# Altium schematic sheet Analog.SchDoc
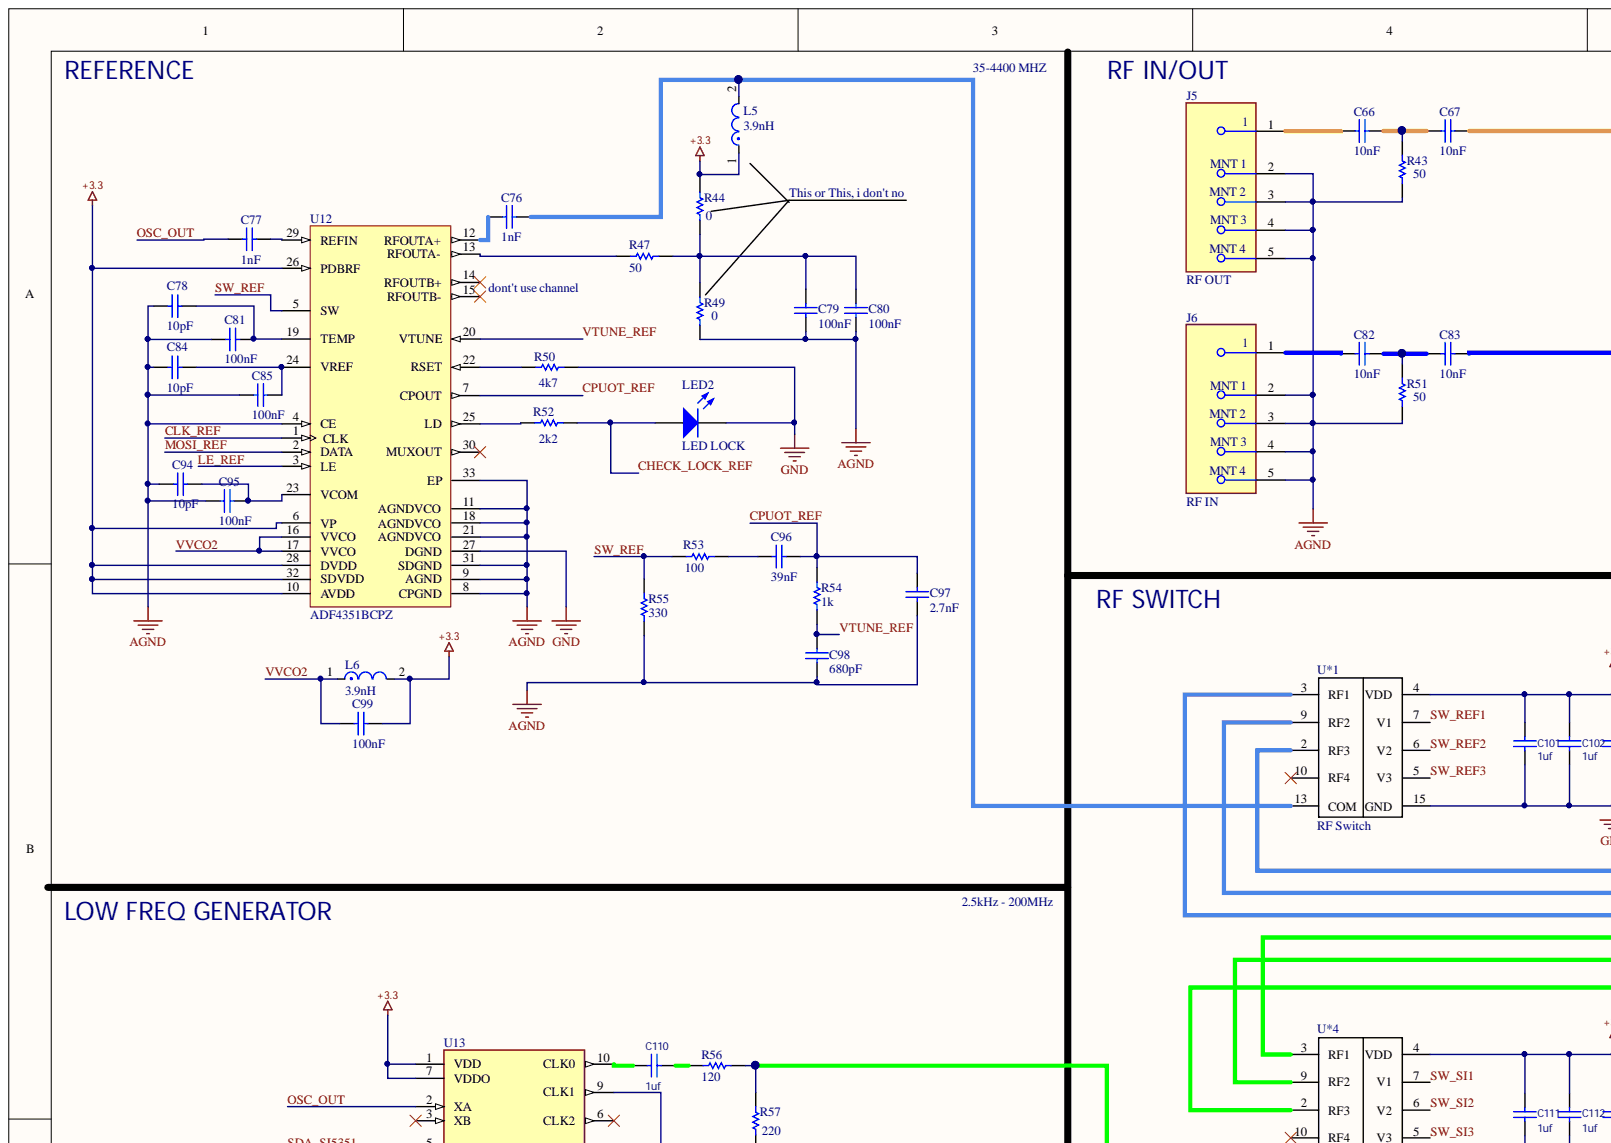
[diagram p0: Analog.SchDoc, top left]
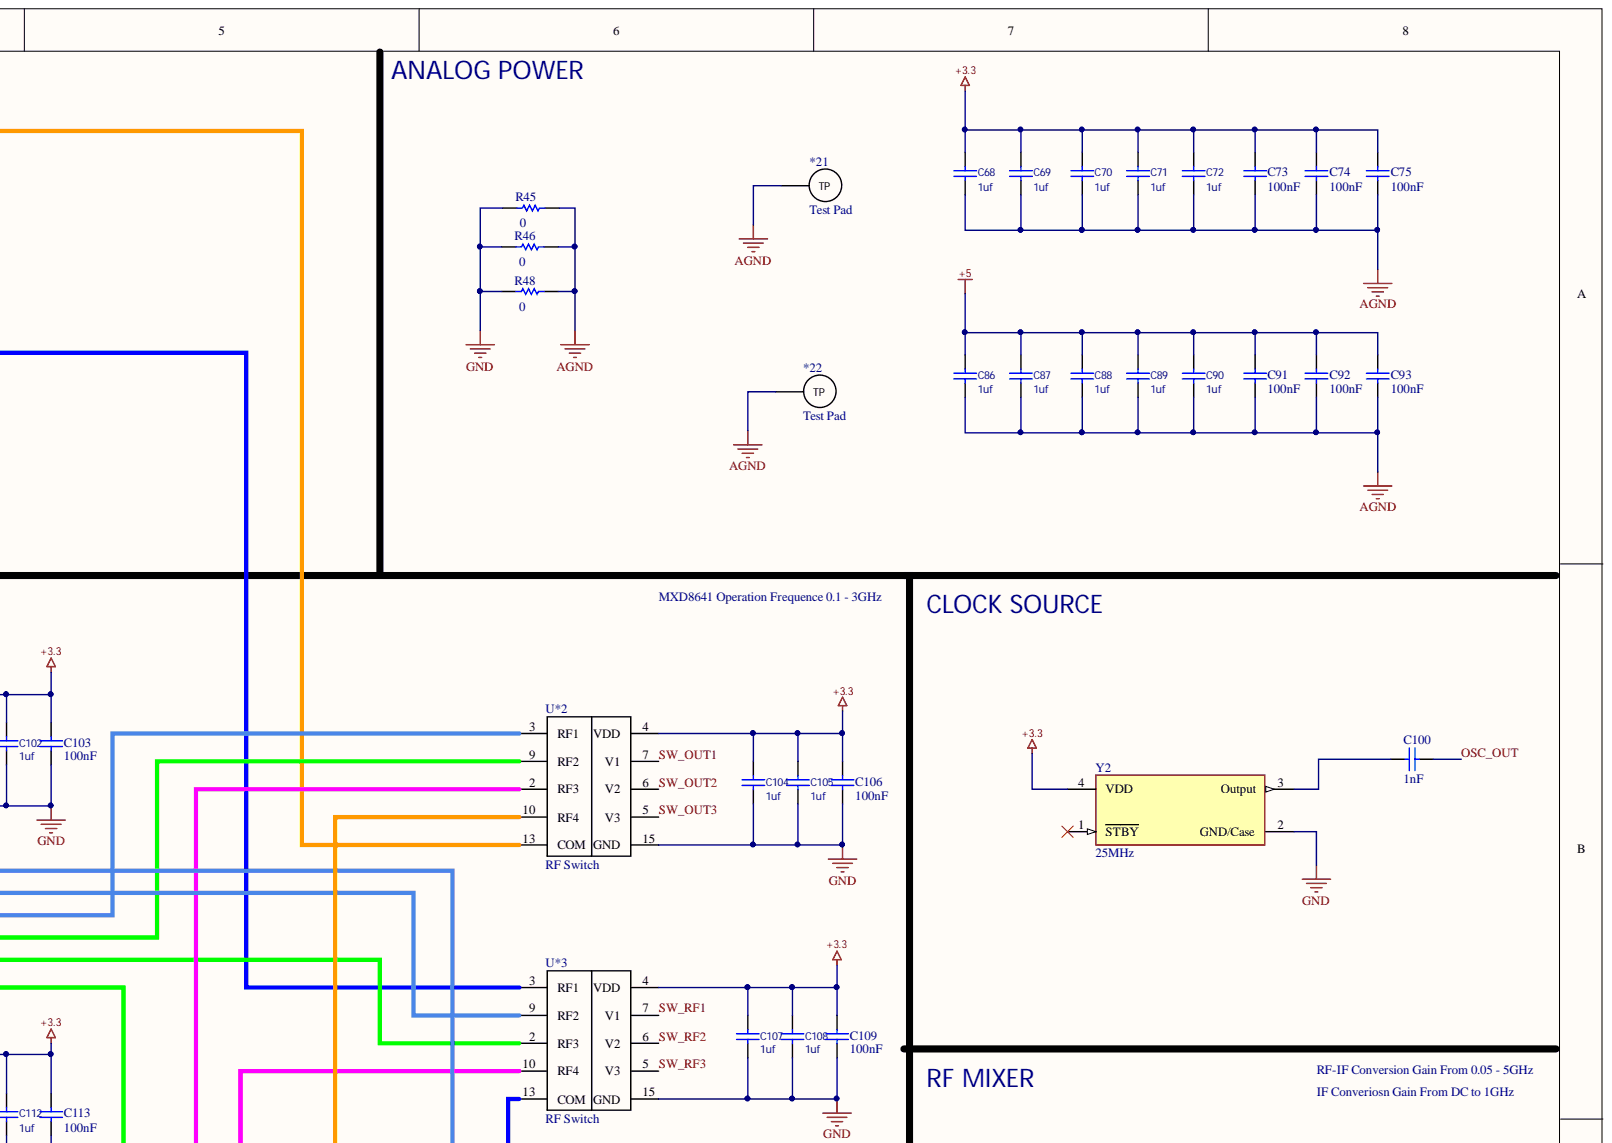
[diagram p1: Analog.SchDoc, top right]
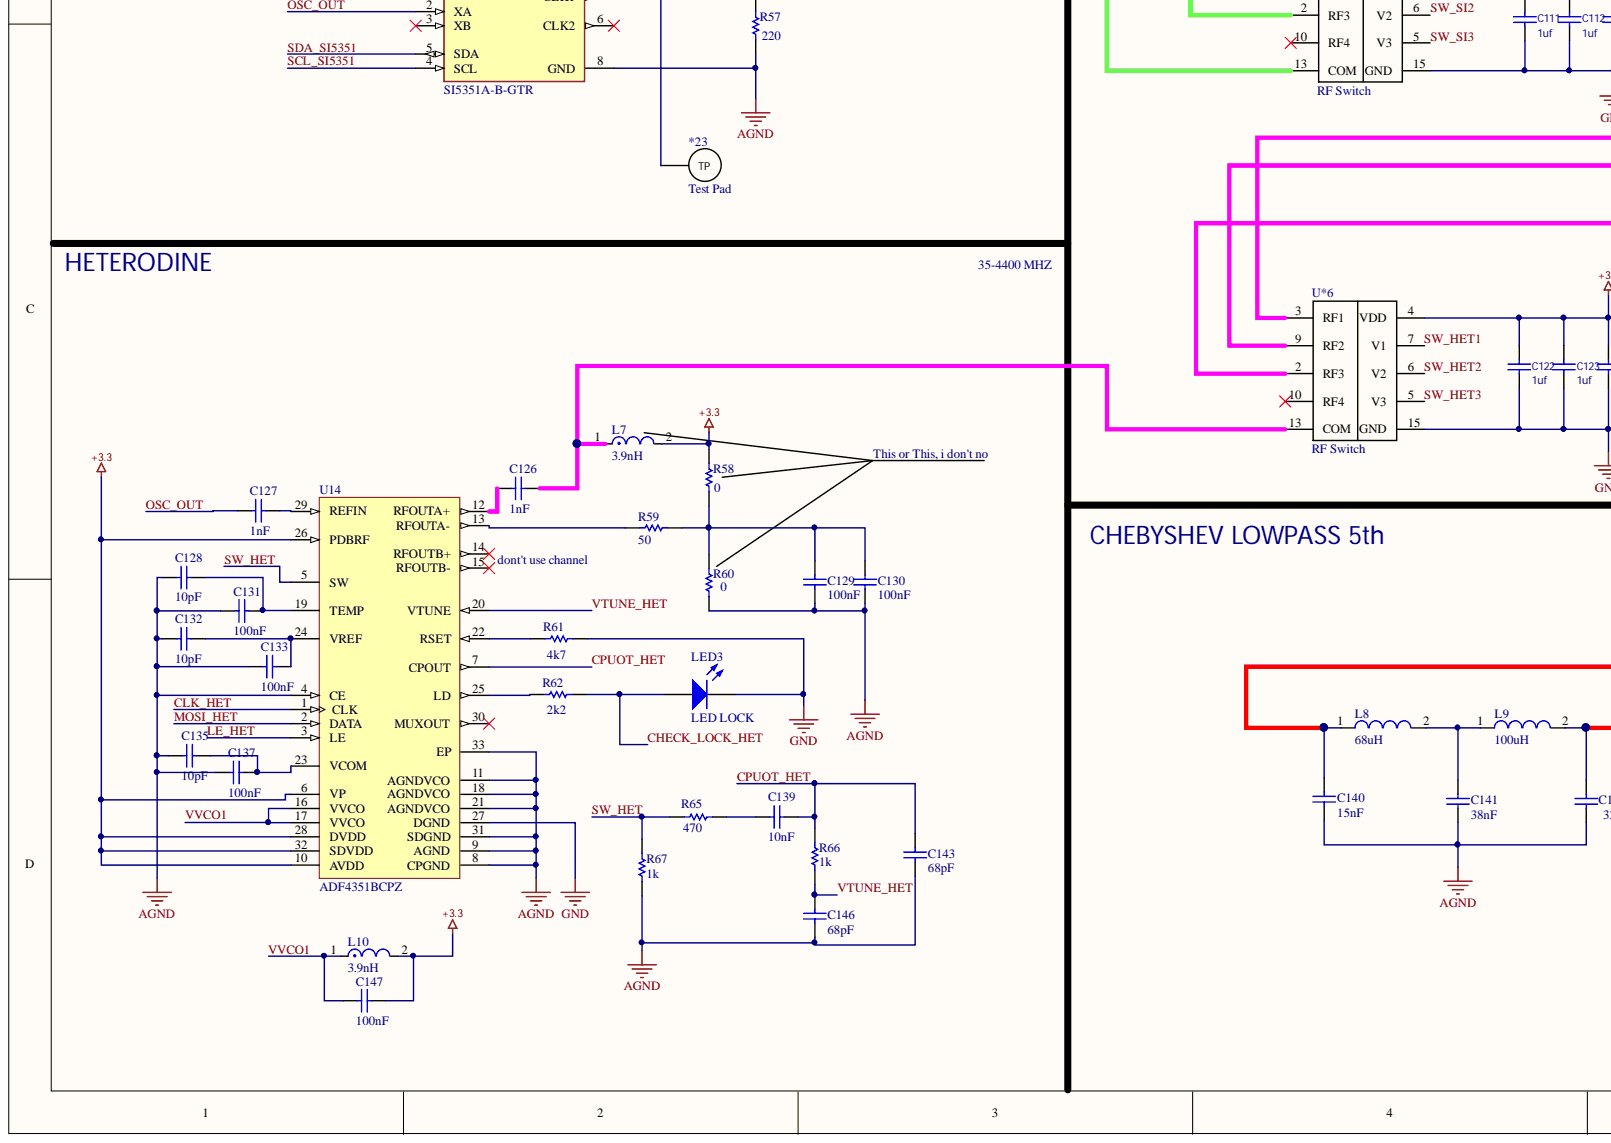
[diagram p2: Analog.SchDoc, bottom left]
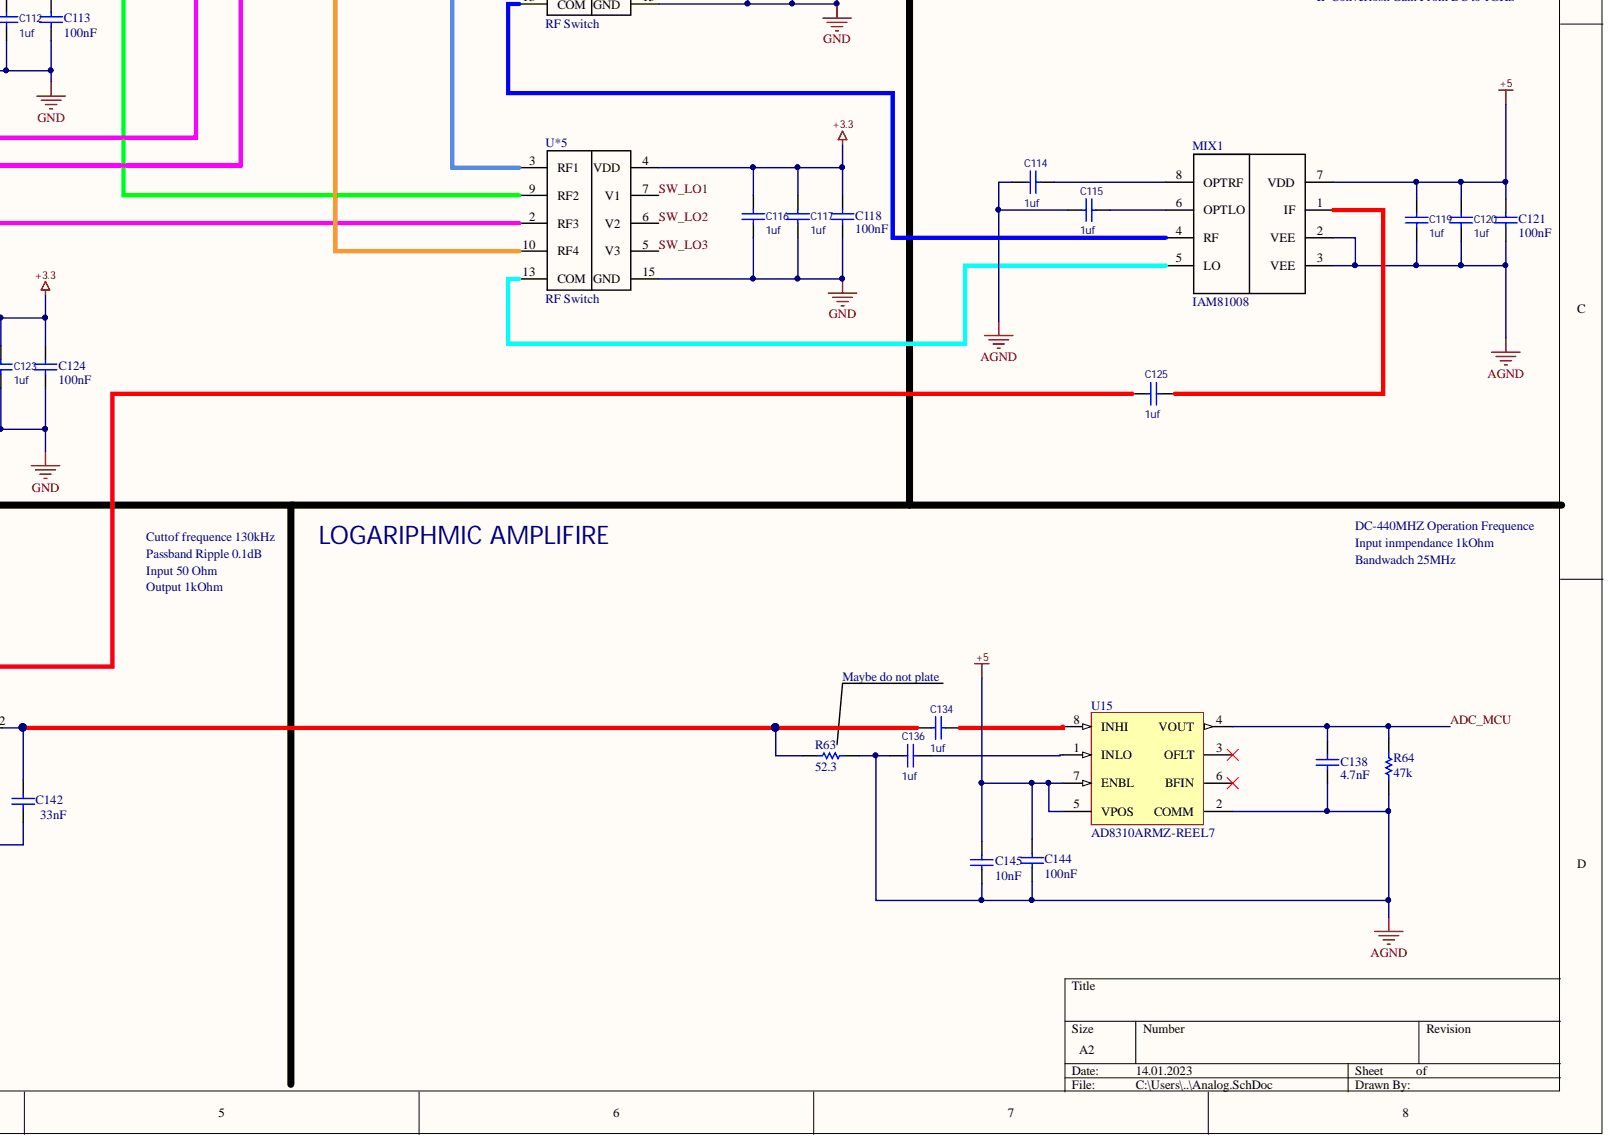
[diagram p3: Analog.SchDoc, bottom right]

## Parts
*21  Test Pad  [Test Pad]
*22  Test Pad  [Test Pad]
*23  Test Pad  [Test Pad]
C66  10nF  [CAPC1608X90X35ML10T15]
C67  10nF  [CAPC1608X90X35ML10T15]
C68  1uf  [CAPC2013X70X45NL10T25]
C69  1uf  [CAPC2013X70X45NL10T25]
C70  1uf  [CAPC2013X70X45NL10T25]
C71  1uf  [CAPC2013X70X45NL10T25]
C72  1uf  [CAPC2013X70X45NL10T25]
C73  100nF  [CAPC1608X90X35ML10T15]
C74  100nF  [CAPC1608X90X35ML10T15]
C75  100nF  [CAPC1608X90X35ML10T15]
C76  1nF  [CAPC1608X90X35ML10T15]
C77  1nF  [CAPC1608X90X35ML10T15]
C78  10pF  [CAPC1608X90X35ML10T15]
C79  100nF  [CAPC1608X90X35ML10T15]
C80  100nF  [CAPC1608X90X35ML10T15]
C81  100nF  [CAPC1608X90X35ML10T15]
C82  10nF  [CAPC1608X90X35ML10T15]
C83  10nF  [CAPC1608X90X35ML10T15]
C84  10pF  [CAPC1608X90X35ML10T15]
C85  100nF  [CAPC1608X90X35ML10T15]
C86  1uf  [CAPC2013X70X45NL10T25]
C87  1uf  [CAPC2013X70X45NL10T25]
C88  1uf  [CAPC2013X70X45NL10T25]
C89  1uf  [CAPC2013X70X45NL10T25]
C90  1uf  [CAPC2013X70X45NL10T25]
C91  100nF  [CAPC1608X90X35ML10T15]
C92  100nF  [CAPC1608X90X35ML10T15]
C93  100nF  [CAPC1608X90X35ML10T15]
C94  10pF  [CAPC1608X90X35ML10T15]
C95  100nF  [CAPC1608X90X35ML10T15]
C96  39nF  [CAPC1608X90X35ML10T15]
C97  2.7nF  [CAPC1608X90X35ML10T15]
C98  680pF  [CAPC1608X90X35ML10T15]
C99  100nF  [CAPC1608X90X35ML10T15]
C100  1nF  [CAPC1608X90X35ML10T15]
C101  1uf  [CAPC2013X70X45NL10T25]
C102  1uf  [CAPC2013X70X45NL10T25]
C103  100nF  [CAPC1608X90X35ML10T15]
C104  1uf  [CAPC2013X70X45NL10T25]
C105  1uf  [CAPC2013X70X45NL10T25]
C106  100nF  [CAPC1608X90X35ML10T15]
C107  1uf  [CAPC2013X70X45NL10T25]
C108  1uf  [CAPC2013X70X45NL10T25]
C109  100nF  [CAPC1608X90X35ML10T15]
C110  1uf  [CAPC2013X70X45NL10T25]
C111  1uf  [CAPC2013X70X45NL10T25]
C112  1uf  [CAPC2013X70X45NL10T25]
C113  100nF  [CAPC1608X90X35ML10T15]
C114  1uf  [CAPC2013X70X45NL10T25]
C115  1uf  [CAPC2013X70X45NL10T25]
C116  1uf  [CAPC2013X70X45NL10T25]
C117  1uf  [CAPC2013X70X45NL10T25]
C118  100nF  [CAPC1608X90X35ML10T15]
C119  1uf  [CAPC2013X70X45NL10T25]
C120  1uf  [CAPC2013X70X45NL10T25]
C121  100nF  [CAPC1608X90X35ML10T15]
C122  1uf  [CAPC2013X70X45NL10T25]
C123  1uf  [CAPC2013X70X45NL10T25]
C124  100nF  [CAPC1608X90X35ML10T15]
C125  1uf  [CAPC2013X70X45NL10T25]
C126  1nF  [CAPC1608X90X35ML10T15]
C127  1nF  [CAPC1608X90X35ML10T15]
C128  10pF  [CAPC1608X90X35ML10T15]
C129  100nF  [CAPC1608X90X35ML10T15]
C130  100nF  [CAPC1608X90X35ML10T15]
C131  100nF  [CAPC1608X90X35ML10T15]
C132  10pF  [CAPC1608X90X35ML10T15]
C133  100nF  [CAPC1608X90X35ML10T15]
C134  1uf  [CAPC2013X70X45NL10T25]
C135  10pF  [CAPC1608X90X35ML10T15]
C136  1uf  [CAPC2013X70X45NL10T25]
C137  100nF  [CAPC1608X90X35ML10T15]
C138  4.7nF  [CAPC1608X90X35ML10T15]
C139  10nF  [CAPC1608X90X35ML10T15]
C140  15nF  [CAPC1608X90X35ML10T15]
C141  38nF  [CAPC1608X90X35ML10T15]
C142  33nF  [CAPC1608X90X35ML10T15]
C143  68pF  [CAPC1608X90X35ML10T15]
C144  100nF  Capacitance: 10nF  [CAPC1608X90X35ML10T15]
C145  10nF  [CAPC1608X90X35ML10T15]
C146  68pF  [CAPC1608X90X35ML10T15]
C147  100nF  [CAPC1608X90X35ML10T15]
J5  RF OUT  [FP-73251-1350-MFG]
J6  RF IN  [FP-73251-1350-MFG]
L5  3.9nH  [FP-LQG18HH_00-MFG]
L6  3.9nH  [FP-LQG18HH_00-MFG]
L7  3.9nH  [FP-LQG18HH_00-MFG]
L8  68uH  [SMD-1210]
L9  100uH  [SMD-1210]
L10  3.9nH  [FP-LQG18HH_00-MFG]
LED2  LED LOCK  [0805_A]
LED3  LED LOCK  [0805_A]
MIX1  IAM81008  [IAM81008]
R43  50  [RESC1608X50X30NL20T20]
R44  0  [RESC1608X50X30NL20T20]
R45  0  [RESC1608X50X30NL20T20]
R46  0  [RESC1608X50X30NL20T20]
R47  50  [RESC1608X50X30NL20T20]
R48  0  [RESC1608X50X30NL20T20]
R49  0  [RESC1608X50X30NL20T20]
R50  4k7  [RESC1608X50X30NL20T20]
R51  50  [RESC1608X50X30NL20T20]
R52  2k2  [RESC1608X50X30NL20T20]
R53  100  [RESC1608X50X30NL20T20]
R54  1k  [RESC1608X50X30NL20T20]
R55  330  [RESC1608X50X30NL20T20]
R56  120  [RESC1608X50X30NL20T20]
R57  220  [RESC1608X50X30NL20T20]
R58  0  [RESC1608X50X30NL20T20]
R59  50  [RESC1608X50X30NL20T20]
R60  0  [RESC1608X50X30NL20T20]
R61  4k7  [RESC1608X50X30NL20T20]
R62  2k2  [RESC1608X50X30NL20T20]
R63  52.3  [RESC1608X50X30NL20T20]
R64  47k  [RESC1608X50X30NL20T20]
R65  470  [RESC1608X50X30NL20T20]
R66  1k  [RESC1608X50X30NL20T20]
R67  1k  [RESC1608X50X30NL20T20]
U12  ADF4351BCPZ  [ADI-CP-32-2_N]
U13  SI5351A-B-GTR  [FP-SI5351A-B-GTR-MFG]
U14  ADF4351BCPZ  [ADI-CP-32-2_N]
U15  AD8310ARMZ-REEL7  [ADI-RM-8_N]
U*1  RF Switch  [PCBComponent_1]
U*2  RF Switch  [PCBComponent_1]
U*3  RF Switch  [PCBComponent_1]
U*4  RF Switch  [PCBComponent_1]
U*5  RF Switch  [PCBComponent_1]
U*6  RF Switch  [PCBComponent_1]
Y2  25MHz  [FP-ASE-MFG]

## Nets
+3.3: C68.2 C69.2 C70.2 C71.2 C72.2 C73.2 C74.2 C75.2 C99.2 C101.2 C102.2 C103.2 C104.2 C105.2 C106.2 C107.2 C108.2 C109.2 C111.2 C112.2 C113.2 C116.2 C117.2 C118.2 C122.2 C123.2 C124.2 C147.2 L5.1 L6.2 L7.2 L10.2 R44.2 R58.2 U12.6(VP) U12.10(AVDD) U12.26(PDBRF) U12.28(DVDD) U12.32(SDVDD) U13.1(VDD) U13.7(VDDO) U14.6(VP) U14.10(AVDD) U14.26(PDBRF) U14.28(DVDD) U14.32(SDVDD) U*1.4(VDD) U*2.4(VDD) U*3.4(VDD) U*4.4(VDD) U*5.4(VDD) U*6.4(VDD) Y2.4(VDD)
+5: C86.2 C87.2 C88.2 C89.2 C90.2 C91.2 C92.2 C93.2 C119.2 C120.2 C121.2 C144.2 C145.2 MIX1.7(VDD) U15.5(VPOS) U15.7(ENBL)
AGND: *21.1 *22.1 C68.1 C69.1 C70.1 C71.1 C72.1 C73.1 C74.1 C75.1 C78.1 C79.1 C80.1 C81.1 C84.1 C85.1 C86.1 C87.1 C88.1 C89.1 C90.1 C91.1 C92.1 C93.1 C94.1 C95.1 C97.1 C98.1 C114.2 C115.2 C119.1 C120.1 C121.1 C128.1 C129.1 C130.1 C131.1 C132.1 C133.1 C135.1 C136.2 C137.1 C138.1 C140.1 C141.1 C142.1 C143.1 C144.1 C145.1 C146.1 J5.2 J5.3 J5.4 J5.5 J6.2 J6.3 J6.4 J6.5 MIX1.2(VEE) MIX1.3(VEE) R43.1 R45.2 R46.2 R48.2 R49.1 R51.1 R55.2 R57.1 R60.1 R63.2 R64.1 R67.2 U12.4(CE) U12.8(CPGND) U12.9(AGND) U12.11(AGNDVCO) U12.18(AGNDVCO) U12.21(AGNDVCO) U12.31(SDGND) U12.33(EP) U13.8(GND) U14.4(CE) U14.8(CPGND) U14.9(AGND) U14.11(AGNDVCO) U14.18(AGNDVCO) U14.21(AGNDVCO) U14.31(SDGND) U14.33(EP) U15.2(COMM)
GND: C101.1 C102.1 C103.1 C104.1 C105.1 C106.1 C107.1 C108.1 C109.1 C111.1 C112.1 C113.1 C116.1 C117.1 C118.1 C122.1 C123.1 C124.1 LED2.1 LED3.1 R45.1 R46.1 R48.1 R50.2 R61.2 U12.27(DGND) U14.27(DGND) U*1.15(GND) U*2.15(GND) U*3.15(GND) U*4.15(GND) U*5.15(GND) U*6.15(GND) Y2.2(GND/Case)
ADC_MCU: C138.2 R64.2 U15.4(VOUT)
CHECK_LOCK_HET: LED3.2 R62.2
CHECK_LOCK_REF: LED2.2 R52.2
CLK_HET: U14.1(CLK)
CLK_REF: U12.1(CLK)
CPUOT_HET: C139.2 C143.2 R66.1 U14.7(CPOUT)
CPUOT_REF: C96.2 C97.2 R54.1 U12.7(CPOUT)
LE_HET: U14.3(LE)
LE_REF: U12.3(LE)
MOSI_HET: U14.2(DATA)
MOSI_REF: U12.2(DATA)
OSC_OUT: C77.1 C100.2 C127.1 U13.2(XA)
SCL_SI5351: U13.4(SCL)
SDA_SI5351: U13.5(SDA)
SW_HET: R65.2 R67.1 U14.5(SW)
SW_HET1: U*6.7(V1)
SW_HET2: U*6.6(V2)
SW_HET3: U*6.5(V3)
SW_LO1: U*5.7(V1)
SW_LO2: U*5.6(V2)
SW_LO3: U*5.5(V3)
SW_OUT1: U*2.7(V1)
SW_OUT2: U*2.6(V2)
SW_OUT3: U*2.5(V3)
SW_REF: R53.2 R55.1 U12.5(SW)
SW_REF1: U*1.7(V1)
SW_REF2: U*1.6(V2)
SW_REF3: U*1.5(V3)
SW_RF1: U*3.7(V1)
SW_RF2: U*3.6(V2)
SW_RF3: U*3.5(V3)
SW_SI1: U*4.7(V1)
SW_SI2: U*4.6(V2)
SW_SI3: U*4.5(V3)
VTUNE_HET: C146.2 R66.2 U14.20(VTUNE)
VTUNE_REF: C98.2 R54.2 U12.20(VTUNE)
VVCO1: C147.1 L10.1 U14.16(VVCO) U14.17(VVCO)
VVCO2: C99.1 L6.1 U12.16(VVCO) U12.17(VVCO)
Net*23_1: *23.1 U13.9(CLK1)
NetC66_1: C66.1 C67.2 R43.2
NetC66_2: C66.2 J5.1
NetC67_1: C67.1 U*2.13(COM)
NetC76_1: C76.1 U12.12(RFOUTA+)
NetC76_2: C76.2 L5.2 U*1.13(COM)
NetC77_2: C77.2 U12.29(REFIN)
NetC78_2: C78.2 C81.2 U12.19(TEMP)
NetC79_2: C79.2 C80.2 R44.1 R47.2 R49.2
NetC82_1: C82.1 C83.2 R51.2
NetC82_2: C82.2 J6.1
NetC83_1: C83.1 U*3.3(RF1)
NetC84_2: C84.2 C85.2 U12.24(VREF)
NetC94_2: C94.2 C95.2 U12.23(VCOM)
NetC96_1: C96.1 R53.1
NetC100_1: C100.1 Y2.3(Output)
NetC110_1: C110.1 R56.2
NetC110_2: C110.2 U13.10(CLK0)
NetC114_1: C114.1 MIX1.8(OPTRF)
NetC115_1: C115.1 MIX1.6(OPTLO)
NetC125_1: C125.1 MIX1.1(IF)
NetC125_2: C125.2 C140.2 L8.1
NetC126_1: C126.1 U14.12(RFOUTA+)
NetC126_2: C126.2 L7.1 U*6.13(COM)
NetC127_2: C127.2 U14.29(REFIN)
NetC128_2: C128.2 C131.2 U14.19(TEMP)
NetC129_2: C129.2 C130.2 R58.1 R59.2 R60.2
NetC132_2: C132.2 C133.2 U14.24(VREF)
NetC134_1: C134.1 U15.8(INHI)
NetC134_2: C134.2 C142.2 L9.2 R63.1
NetC135_2: C135.2 C137.2 U14.23(VCOM)
NetC136_1: C136.1 U15.1(INLO)
NetC139_1: C139.1 R65.1
NetC141_2: C141.2 L8.2 L9.1
NetMIX1_4: MIX1.4(RF) U*3.13(COM)
NetMIX1_5: MIX1.5(LO) U*5.13(COM)
NetR47_1: R47.1 U12.13(RFOUTA-)
NetR50_1: R50.1 U12.22(RSET)
NetR52_1: R52.1 U12.25(LD)
NetR56_1: R56.1 R57.2 U*4.13(COM)
NetR59_1: R59.1 U14.13(RFOUTA-)
NetR61_1: R61.1 U14.22(RSET)
NetR62_1: R62.1 U14.25(LD)
NetU*1_2: U*1.2(RF3) U*5.3(RF1)
NetU*1_3: U*1.3(RF1) U*2.3(RF1)
NetU*1_9: U*1.9(RF2) U*3.9(RF2)
NetU*2_2: U*2.2(RF3) U*6.3(RF1)
NetU*2_9: U*2.9(RF2) U*4.3(RF1)
NetU*2_10: U*2.10(RF4) U*5.10(RF4)
NetU*3_2: U*3.2(RF3) U*4.9(RF2)
NetU*3_10: U*3.10(RF4) U*6.9(RF2)
NetU*4_2: U*4.2(RF3) U*5.9(RF2)
NetU*5_2: U*5.2(RF3) U*6.2(RF3)

Not connected: U12 14(RFOUTB+) 15(RFOUTB-) 30(MUXOUT); U13 3(XB) 6(CLK2); U14 14(RFOUTB+) 15(RFOUTB-) 30(MUXOUT); U15 3(OFLT) 6(BFIN); U*1 10(RF4); U*4 10(RF4); U*6 10(RF4); Y2 1(STBY)

## Library data of the parts
C66 C67 C82 C83 C139 C144 C145 = CMP-2100-03642-1: Chip Capacitor, 10 nF, +/- 10%, 50 V, -55 to 125 degC, 0603 (1608 Metric), RoHS, Tape and Reel; Manufacturer: Murata; Dielectric: X7R; Dielectric Material: Ceramic; Insulation Resistance: 10GR; Voltage: 50V; Case/Package: 0603; Case Code (Imperial): 0603; Case Code (Metric): 1608; Component Kind: Standard; Datasheet URL: http://search.murata.co.jp/Ceramy/image/img/A01X/G101/ENG/GRM188R71H103KA01-01.pdf; Datasheet Version: 42401.0; Depth: 0.8mm; Height: 0.8mm; Lead Free: LeadFree; Length: 1.6mm; Library Name: Altium Content Vault; Manufacturer URL: http://www.murata.com/; Material: Ceramic; Max Operating Temperature: 125degC; Min Operating Temperature: -55degC; Mount: SurfaceMount; Number of Pins: 2; Package Description: 2-Pin Surface Mount Device, Body 1.6 x 0.8 mm; Package Quantity: 1; Package Reference: 0603; Packaging: TapeandReel; REACH SVHC: Unknown; RoHSCompliant: True; Termination: SMD/SMT; Thickness: 0.035inch; Tolerance: 10%; Voltage Rating: 50V; Voltage Rating (DC): 50V; Width: 0.031inch
  C66 C67 C82 C83 C139 C145: Capacitance: 10nF
C68-C72 C86-C90 C101 C102 C104 C105 C107 C108 C110-C112 C114-C117 C119 C120 C122 C123 C125 C134 C136 = CMP-2007-00957-1: Manufacturer: Murata; Part Number: GRM216R61E105KA12D; Component Kind: Standard; Library Name: Altium Content Vault
C73-C81 C84 C85 C91-C100 C103 C106 C109 C113 C118 C121 C124 C126-C133 C135 C137 C138 C140-C143 C146 C147 = CMP-2100-03642-1: Chip Capacitor, 10 nF, +/- 10%, 50 V, -55 to 125 degC, 0603 (1608 Metric), RoHS, Tape and Reel; Manufacturer: Murata; Capacitance: 10nF; Dielectric: X7R; Dielectric Material: Ceramic; Insulation Resistance: 10GR; Voltage: 50V; Case/Package: 0603; Case Code (Imperial): 0603; Case Code (Metric): 1608; Component Kind: Standard; Datasheet URL: http://search.murata.co.jp/Ceramy/image/img/A01X/G101/ENG/GRM188R71H103KA01-01.pdf; Datasheet Version: 42401.0; Depth: 0.8mm; Height: 0.8mm; Lead Free: LeadFree; Length: 1.6mm; Library Name: Altium Content Vault; Manufacturer URL: http://www.murata.com/; Material: Ceramic; Max Operating Temperature: 125degC; Min Operating Temperature: -55degC; Mount: SurfaceMount; Number of Pins: 2; Package Description: 2-Pin Surface Mount Device, Body 1.6 x 0.8 mm; Package Quantity: 1; Package Reference: 0603; Packaging: TapeandReel; REACH SVHC: Unknown; RoHSCompliant: True; Termination: SMD/SMT; Thickness: 0.035inch; Tolerance: 10%; Voltage Rating: 50V; Voltage Rating (DC): 50V; Width: 0.031inch
J5 J6 = CMP-04764-000006-1: CONN SMA JACK STR 50 OHM SMD; Manufacturer: Molex; Manufacturer Part Number: 73251-1350; Conn Orientation: Straight; Frequency: 18GHz; Impedance: 50Ω; Is Female: yes; Number Of Contacts: 1; Shielding: true; Standoff Height: 0.41mm; Voltage Rating: 500V; Automotive: No; Category: Conn; Component Kind: Standard; ComponentLink2Description: Datasheet; ComponentLink2URL: https://www.molex.com/pdm_docs/sd/732511350_sd.pdf; Contact Material: Gold; Device Class L1: Connectors; Device Class L2: RF / Coaxial Connectors; Device Class L3: unset; Digikey Description: CONN SMA JACK STR 50 OHM SMD; Footprint Url: https://forum.upverter.com/uploads/default/original/3X/d/a/daeafa2ce4908d4fc4e49f0b17d7d2c986fce444.pdf; Height: 9.655mm; Lead Free: Yes; Library Name: Altium Content Vault; Mouser Description: RF Connectors / Coaxial Connectors SMA ST PCB-JCK STD SMT; Octopart: https://octopart.com/73251-1350-molex-5414390; Package: 73251-1350; RoHS: TRUE; Temperature: -65°C to +165°C; Temperature Range High: +165°C; Temperature Range Low: -65°C
L5-L7 L10 = CMP-06042-004616-1: Multilayer type RF Inductor 10nH ±5% 0.3Ω 650mA 0603; Core Material: Air; Current: 35mA; Current Rating: 400mA; DC Resistance (DCR): 300mR; Q Factor: 12; Self Resonant Frequency: 3.5GHz; Shielding: Unshielded; Test Frequency: 100MHz; Case/Package: 0603; Case Code (Imperial): 0603; Case Code (Metric): 1608; Component Kind: Standard; DC Current: 400mA; Depth: 0.95mm; Height: 0.8mm; Inductance: 10nH; Inductance Tolerance: 5%; Lead Free: Lead Free; Length: 1.6mm; Library Name: Altium Content Vault; Max DC Current: 400mA; Max Operating Temperature: 85°C; Military Standard: Not; Min Operating Temperature: -40°C; Mount: Surface Mount; Package Quantity: 4000; Packaging: Tape and Reel; Radiation Hardening: No; REACH SVHC: No SVHC; Termination: SMD/SMT; Tolerance: 5%; Width: 0.031inch
L8 L9 = CMP-0221-00030-1: SMD-Multilayer Inductor WE-MI, L = 10.00 µH; Inductance: 10.00 ÂµH; Component Kind: Standard; ComponentLink1Description: Manufacturer Link; ComponentLink1URL: http://www.we-online.com; ComponentLink2Description: Datasheet; ComponentLink2URL: http://www.we-online.de/katalog/media/pdf/7447918.pdf; DatasheetVersion: ver 5, Oct-2004; Library Name: Altium Content Vault; PackageDescription: Chip Inductor, 2-Leads, Body 3.2 x 2.5 mm; PackageReference: SMD-1210; PackageVersion: 2007
LED2 LED3 = CMP-1426-00011-1: SMD mono-color Chip LED, WL-SMCW, Red; Manufacturer: Wuerth Elektronik; PartNumber: 150080RS75000; Color: Red; Component Kind: Standard; ComponentLink1Description: Manufacturer URL; ComponentLink1URL: http://www.we-online.com; ComponentLink2Description: Datasheet; ComponentLink2URL: http://katalog.we-online.com/led/datasheet/150080RS75000.pdf; Library Name: Altium Content Vault; PackageReference: 0805_A
R43-R67 = CMP-2000-02744-1: Manufacturer: Susumu; Part Number: RR0816P-753-B-T5; Component Kind: Standard; Library Name: Altium Content Vault
U12 U14 = CMP-0287-00014-1: Wideband Synthesizer with Integrated VCO, CP-32-2, Pb-Free, 490 Tray; Frequency Range: 35MHz-4.4GHz; Max REFin (MHz): 250MHz; Max RF Input (MHz): 4.4GHz; Norm Phase Noise (dBc/Hz): -221dBc; RF Prescalers: 4/5,8/9; Single/Dual: Single; Supply Current: 110mA; Code_JEDEC: MO-220-VHHD-2; Component Kind: Standard; ComponentLink1Description: Manufacturer URL; ComponentLink1URL: www.analog.com; ComponentLink2Description: Datasheet; ComponentLink2URL: http://www.analog.com/static/imported-files/data_sheets/ADF4351.pdf; ComponentLink3Description: Package Specification; ComponentLink3URL: http://www.analog.com/static/imported-files/packages/414143737956480539664569CP_32_2.pdf; DatasheetVersion: Rev. Pr D; Library Name: Altium Content Vault; PackageDescription: 32-Lead Lead Frame Chip Scale Package [LFCSP_VQ]; PackageReference: CP-32-2; PackageVersion: Jan-2005
U13 = CMP-08072-000001-1: IC CLK GENERATOR 200MHZ 10MSOP; Input: Crystal; Max Duty Cycle: 55%; Number of Circuits: 2; Number of Outputs: 3; Operating Supply Current: 22mA; Operating Supply Voltage: 3.3V; PLL: Yes; Case/Package: MSOP; Component Kind: Standard; Library Name: Altium Content Vault; Max Frequency: 200MHz; Max Operating Temperature: 85°C; Min Operating Temperature: -40°C; Mount: Surface Mount; Number of Pins: 10; Package Quantity: 2500; Packaging: Tape and Reel; RoHS Compliant: Yes; Series: MultiSynth™
U15 = CMP-0284-00103-1: Fast, Voltage-Out, DC to 440 MHz, 95 dB Logarithmic Amplifier, 15ns Response Time, RM-8, Pb-Free, 1000 Reel; Dynamic Range (dB): 100dB; Product Description: High Dynamic Range; Supply Current: 8mA; Temp Stability (dB): 1dB; Voltage Supply (V): 2.7 to 5.5; Code_JEDEC: MO-187-AA; Component Kind: Standard; ComponentLink1Description: Manufacturer URL; ComponentLink1URL: www.analog.com; ComponentLink2Description: Datasheet; ComponentLink2URL: http://www.analog.com/static/imported-files/data_sheets/AD8310.pdf; ComponentLink3Description: Package Specification; ComponentLink3URL: http://www.analog.com/static/imported-files/packages/PKG_PDF/MSOP%28RM%29/RM_8.pdf; DatasheetVersion: Rev. F; Library Name: Altium Content Vault; PackageDescription: 8-Lead Mini Small Outline Package [MSOP]; PackageReference: RM-8; PackageVersion: Aug-2005; Response Time (ns typ): 15ns; RF Frequency (MHz): dc to 440
U*1-U*6 = MXD8641: Component Kind: Standard; Library Name: Integrated_Library_MXD8641.IntLib
Y2 = CMP-27762-010648-1: XTAL Oscillator 33.333MHz ±50ppm CMOS 3.3V 4-SMD 3.2mm x 2.5mm; Load Capacitance: 15pF; Max Supply Current: 13mA; Max Supply Voltage: 3.465V; Min Supply Voltage: 3.135V; Case/Package: SMD/SMT; Component Kind: Standard; Depth: 2.5mm; Frequency: 33.333MHz; Frequency Stability: 50ppm; Height: 0.047inch; Lead Free: Lead Free; Length: 3.2mm; Library Name: Altium Content Vault; Max Operating Temperature: 85°C; Min Operating Temperature: -40°C; Mount: Surface Mount; Number of Pins: 4; Operating Frequency: 33.333 MHz; Operating Supply Voltage: 3.3V; Package Quantity: 1000; Packaging: Tape and Reel; Radiation Hardening: No; REACH SVHC: No SVHC; Series: ASE; Termination: SMD/SMT; Width: 0.098inch
*21-*23 = Test Pad: Component Kind: Standard; Library Name: Test Pad.SchLib
MIX1 = IAM81008: Component Kind: Standard; ComponentLink1Description: https://www.chipfind.ru/datasheet/agilent/iam81008.htm; Library Name: IAM81008.IntLib
